# Altium schematic sheet Output.SchDoc
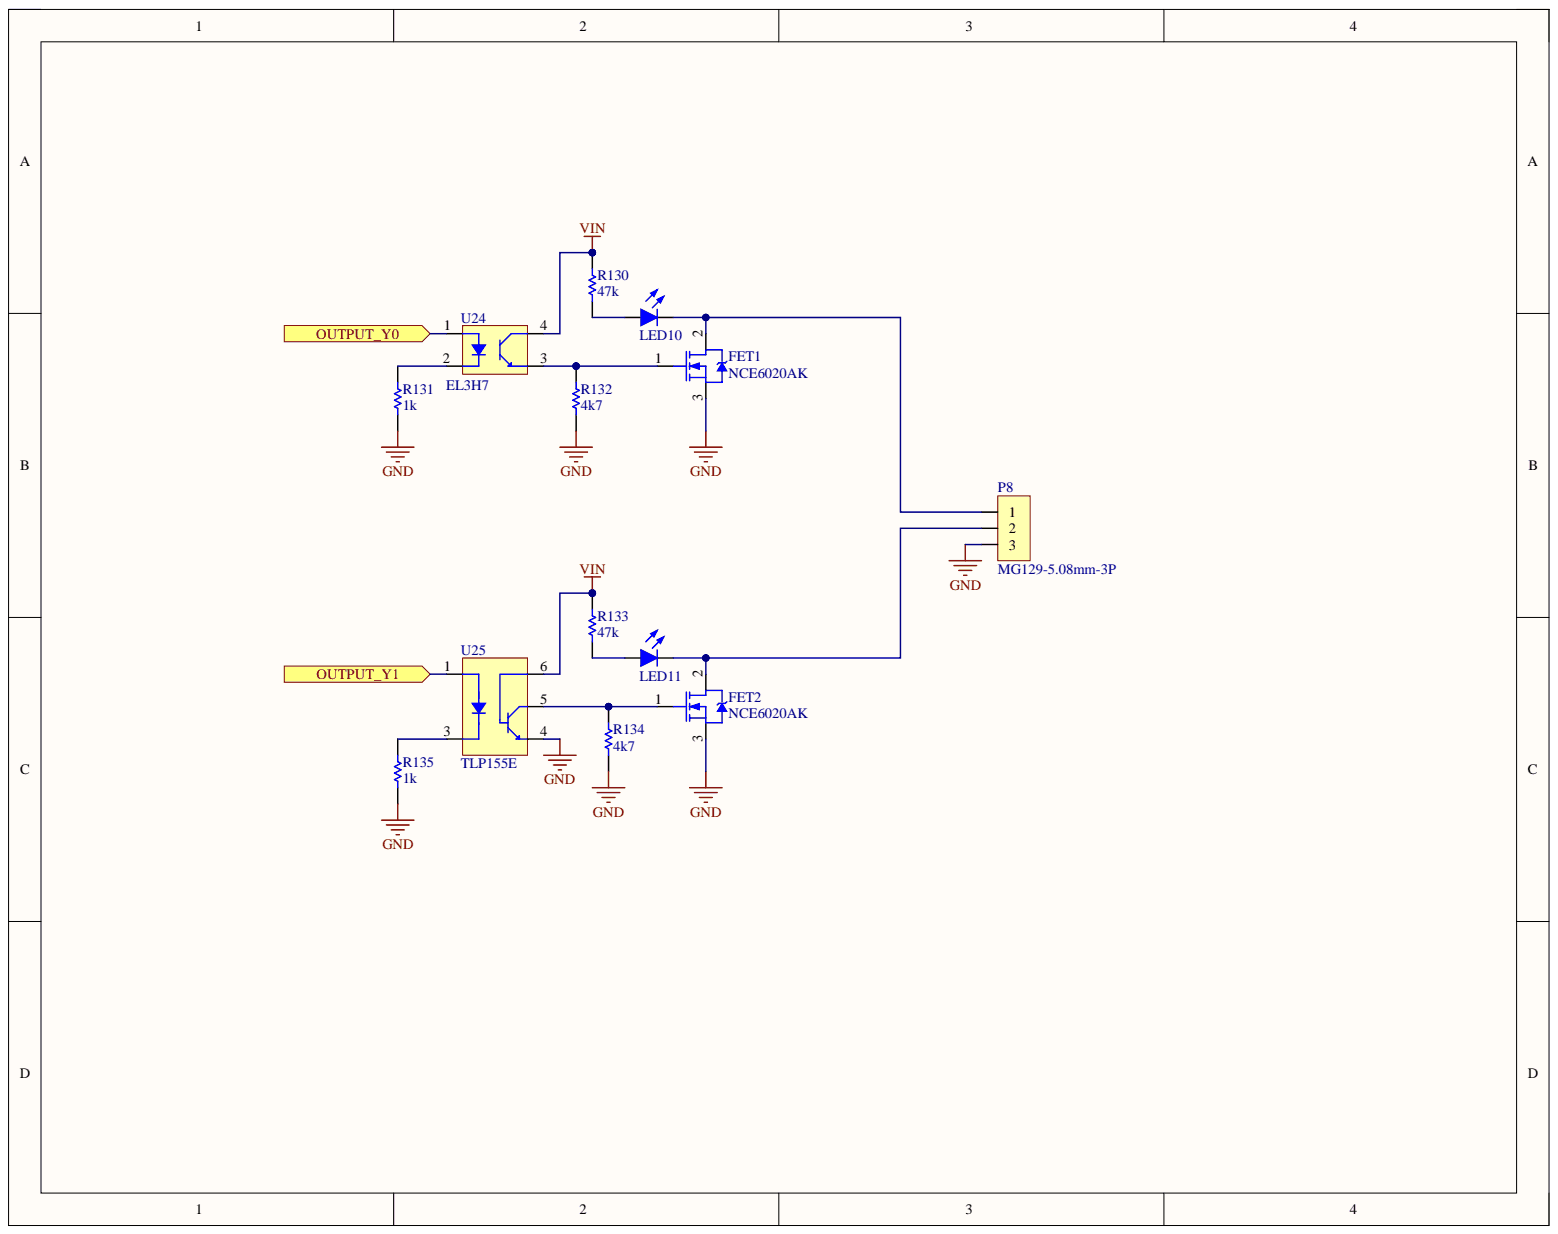
[diagram p0: Output.SchDoc]

## Parts
FET1  NCE6020AK  [TO-252 (DPAK)]
FET2  NCE6020AK  [TO-252 (DPAK)]
LED10  Led-Green  [Led SMD 0603 GREEN]  (value not drawn)
LED11  Led-Green  [Led SMD 0603 GREEN]  (value not drawn)
P8  MG129-5.08mm-3P  [HDR Domino 3 - 5MM]
R130  47k  [R 0603 (1608)]
R131  1k  [R 0603 (1608)]
R132  4k7  [R 0603 (1608)]
R133  47k  [R 0603 (1608)]
R134  4k7  [R 0603 (1608)]
R135  1k  [R 0603 (1608)]
U24  EL3H7  [SOIC-4]
U25  TLP155E  [SO-5 HCPL]

## Ports
OUTPUT_Y0, OUTPUT_Y1

## Nets
GND: FET1.3 FET2.3 P8.3 R131.2 R132.1 R134.1 R135.2 U25.4
VIN: R130.2 R133.2 U24.4 U25.6
OUTPUT_Y0: U24.1 ; port OUTPUT_Y0
OUTPUT_Y1: U25.1 ; port OUTPUT_Y1
NetFET1_1: FET1.1 R132.2 U24.3
NetFET1_2: FET1.2 LED10.2 P8.1
NetFET2_1: FET2.1 R134.2 U25.5
NetFET2_2: FET2.2 LED11.2 P8.2
NetLED10_1: LED10.1 R130.1
NetLED11_1: LED11.1 R133.1
NetR131_1: R131.1 U24.2
NetR135_1: R135.1 U25.3

## Library data of the parts
FET1 FET2 = MOSFET N-Channel: Component Kind: Standard; Description: MOSFET N-Channel; Library Name: BKIT4U_LIB.SchLib
LED10 LED11 = Led: Typical LED; Component Kind: Standard; Library Name: BKIT4U_LIB.SchLib
P8 = Header 3: Header, 3-Pin; Component Kind: Standard; Library Name: BKIT4U_LIB.SchLib
R130-R135 = Resistor: Component Kind: Standard; Description: Resistor; LatestRevisionDate: 17-Jul-2002; LatestRevisionNote: Re-released for DXP Platform.; Library Name: BKIT4U_LIB.SchLib; PackageReference: AXIAL-0.3; Published: 8-Jun-2000; Publisher: Altium Limited
U24 = Opto PC817B: Component Kind: Standard; Library Name: BKIT4U_LIB.SchLib
U25 = Opto PC410L: High Speed 10Mb/s, High CMR  Mini-flat Package ∗OPIC  Photocoupler; Component Kind: Standard; Library Name: BKIT4U_LIB.SchLib
